# Altium schematic sheet Pag4_Processador.SchDoc(Pag4_Processador)
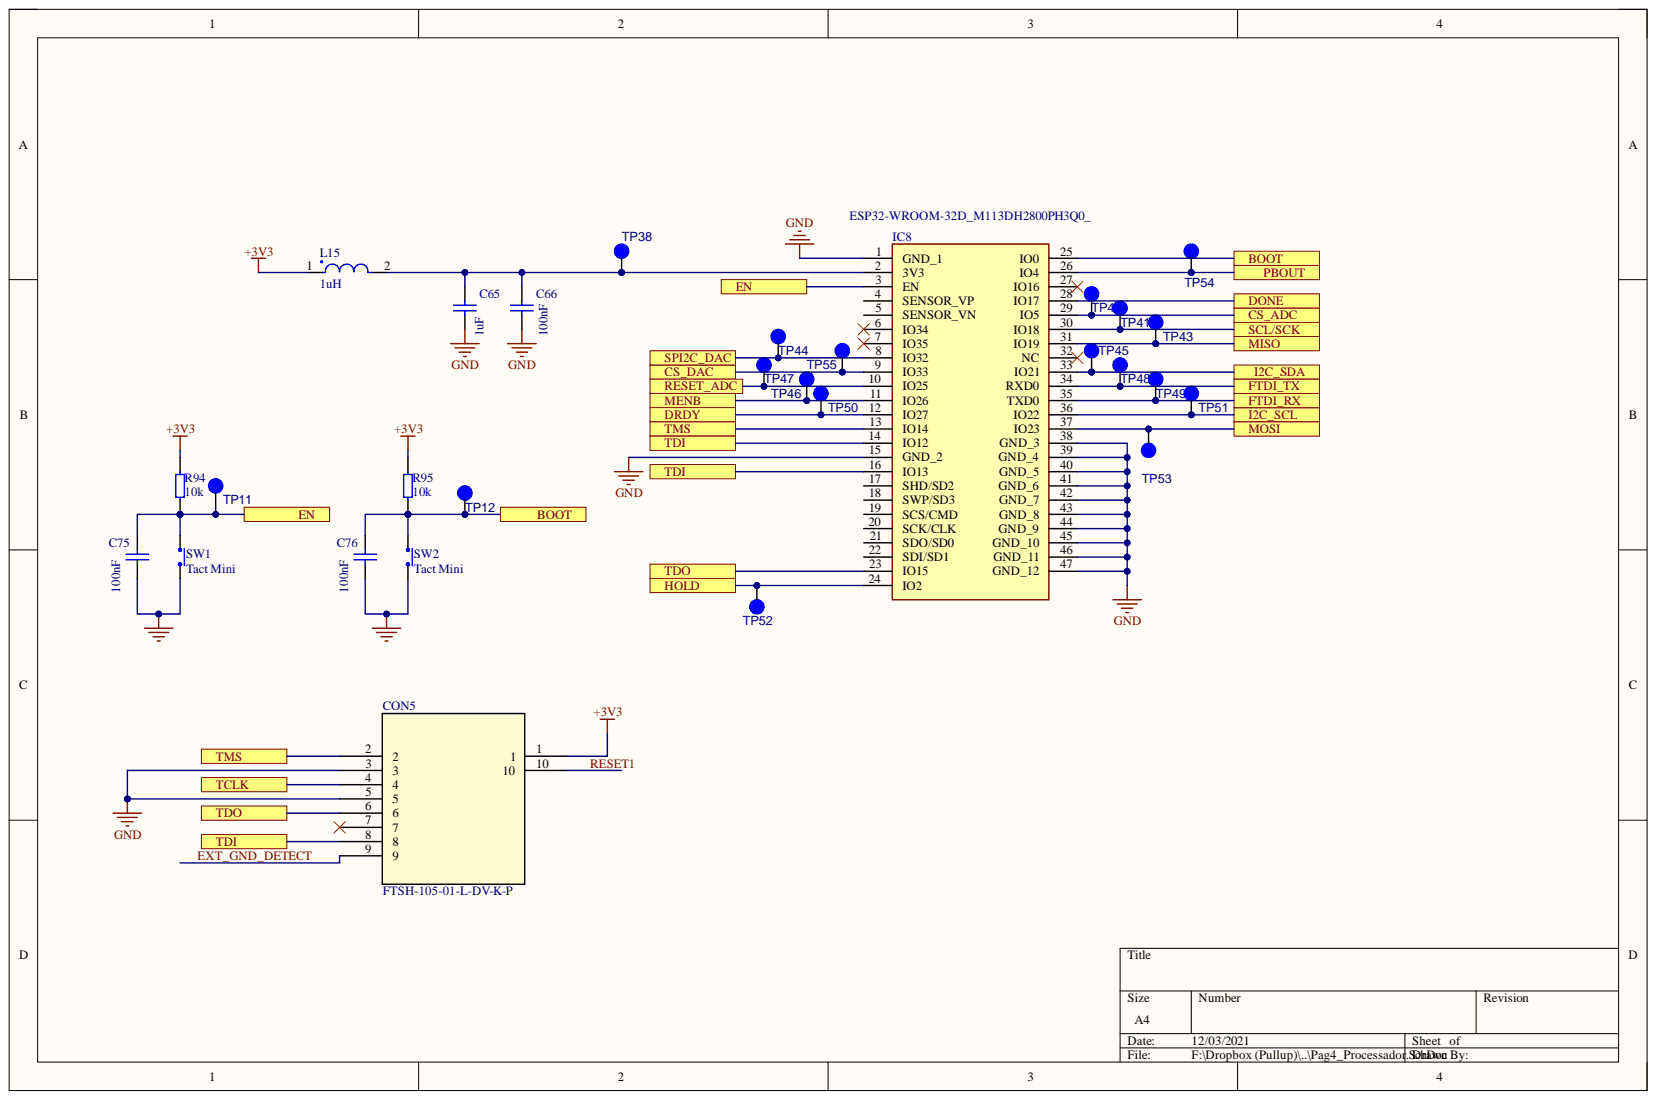
[diagram p0: Pag4_Processador.SchDoc]

## Parts
C65  1uF  [0603]
C66  100nF  [0603]
C75  100nF  [0603]
C76  100nF  [0603]
CON5  FTSH-105-01-L-DV-K-P  [CON10_2X5_DUK_FTSH_SAI]
IC8  ESP32-WROOM-32D_M113DH2800PH3Q0_  [ESP32WROOM32DM113DH2800PH3Q0]
L15  1uH  [SRP4012TA]
R94  10k  [0603]
R95  10k  [0603]
SW1  Tact Mini  [MSLPT5252BG1MTR_pullup]
SW2  Tact Mini  [MSLPT5252BG1MTR_pullup]
TP11  =value  [TEST]
TP12  =value  [TEST]
TP38  =value  [TEST]
TP40  =value  [TEST]
TP41  =value  [TEST]
TP43  =value  [TEST]
TP44  =value  [TEST]
TP45  =value  [TEST]
TP46  =value  [TEST]
TP47  =value  [TEST]
TP48  =value  [TEST]
TP49  =value  [TEST]
TP50  =value  [TEST]
TP51  =value  [TEST]
TP52  =value  [TEST]
TP53  =value  [TEST]
TP54  =value  [TEST]
TP55  =value  [TEST]

## Ports
BOOT, CS_ADC, CS_DAC, DONE, DRDY, EN, FTDI_RX, FTDI_TX, HOLD, I2C_SCL, I2C_SDA, MENB, MISO, MOSI, PBOUT, RESET_ADC, SCL/SCK, SPI2C_DAC, TCLK, TDI, TDO, TMS

## Nets
+3V3: CON5.1 L15.1 R94.2 R95.2
GND: C65.1 C66.1 C75.1 C76.1 CON5.3 CON5.5 IC8.1(GND_1) IC8.15(GND_2) IC8.38(GND_3) IC8.39(GND_4) IC8.40(GND_5) IC8.41(GND_6) IC8.42(GND_7) IC8.43(GND_8) IC8.44(GND_9) IC8.45(GND_10) IC8.46(GND_11) IC8.47(GND_12) SW1.1 SW2.1
BOOT: C76.2 IC8.25(IO0) R95.1 SW2.2 TP12.1 ; port BOOT
CS_ADC: IC8.29(IO5) TP40.1 ; port CS_ADC
CS_DAC: IC8.9(IO33) TP55.1 ; port CS_DAC
DONE: IC8.28(IO17) ; port DONE
DRDY: IC8.12(IO27) TP50.1 ; port DRDY
EN: C75.2 IC8.3(EN) R94.1 SW1.2 TP11.1 ; port EN
EXT_GND_DETECT: CON5.9
FTDI_RX: IC8.35(TXD0) TP49.1 ; port FTDI_RX
FTDI_TX: IC8.34(RXD0) TP48.1 ; port FTDI_TX
HOLD: IC8.24(IO2) TP52.1 ; port HOLD
I2C_SCL: IC8.36(IO22) TP51.1 ; port I2C_SCL
I2C_SDA: IC8.33(IO21) TP45.1 ; port I2C_SDA
MENB: IC8.11(IO26) TP46.1 ; port MENB
MISO: IC8.31(IO19) TP43.1 ; port MISO
MOSI: IC8.37(IO23) TP53.1 ; port MOSI
PBOUT: IC8.26(IO4) TP54.1 ; port PBOUT
RESET1: CON5.10
RESET_ADC: IC8.10(IO25) TP47.1 ; port RESET_ADC
SCL/SCK: IC8.30(IO18) TP41.1 ; port SCL/SCK
SPI2C_DAC: IC8.8(IO32) TP44.1 ; port SPI2C_DAC
TCLK: CON5.4 ; port TCLK
TDI: CON5.8 IC8.14(IO12) IC8.16(IO13) ; port TDI
TDO: CON5.6 IC8.23(IO15) ; port TDO
TMS: CON5.2 IC8.13(IO14) ; port TMS
NetC65_2: C65.2 C66.2 IC8.2(3V3) L15.2 TP38.1

Not connected: CON5 7; IC8 4(SENSOR_VP) 5(SENSOR_VN) 6(IO34) 7(IO35) 17(SHD/SD2) 18(SWP/SD3) 19(SCS/CMD) 20(SCK/CLK) 21(SDO/SD0) 22(SDI/SD1) 27(IO16) 32(NC)

## Library data of the parts
C65 = (no symbol name): Manufacturer: Samsung Electro-Mechanics; MPN: CL10A105KA8NNNC; PCBA: Assembly; Component Kind: Standard; Datasheet: https://www.mouser.com/datasheet/2/585/MLCC-1837944.pdf; Supplier 1: Mouser; Supplier 1 Link: https://www.mouser.com/ProductDetail/Samsung-Electro-Mechanics/CL10A105KA8NNNC?qs=hqM3L16%252BxldY%252BnK4KzDJVg%3D%3D; Supplier 1 Part Number: 187-CL10A105KA8NNNC; Value: 1uF; Library Name: potenciostato.SCHLIB
C66 C75 C76 = (no symbol name): Capacitor Ceramic 100nF 10% 16V 0603 (1608 Metric); Manufacturer: Kemet; MPN: C0603C104K4RACTU; Alternate: AA; CPqD PN: Pullup_Cap_100nF_0603_CAP; Engineering Change Status Code: Approved; Extra Info: - 55 C to + 125 C; LeadTime: 12 wks; Model_File: CAP; PCB INFO: Assembly; Temperature Coefficient: X7R; Complement Value: 16V; Component Kind: Standard; Component Type: Standard; Package: 0603 (1608 Metric); Price: 0,012; RoHS: SIM; Size / Dimension: 0.8 mm W x 1.6 mm L x 0.8 mm H; SVN_Link Data Sheet: http://svn.cpqd.com.br/DRT/biblio_comp/tags/datasheets/capacitor/kemet.pdf; Tolerance: 10%; Type: Capacitor Ceramic; Value: 100nF; Web_Link Data Sheet: http://www.kemet.com/kemet/web/homepage/kechome.nsf/vapubfiles/KEM_C1002_X7R_SMD.pdf/$file/KEM_C1002_X7R_SMD.pdf; Library Name: potenciostato.SCHLIB
CON5 = FTSH-105-01-L-DV-K-P: No Description Available; Manufacturer: Samtec; Mfr_Name: Samtec Inc; MPN: FTSH-105-01-L-DV-K-P-TR; PCBA: Assembly; Assembly: Assembly; Component Kind: Standard; Datasheet: https://www.mouser.com/datasheet/2/527/ftsh_smt-1316912.pdf; Library Name: FTSH-105-01-L-DV-K-P.SchLib; Manufacturer_Part_Number: FTSH-105-01-L-DV-K-P; RefDes: RefDes; Supplier 1: Mouser; Supplier 1 Link: https://www.mouser.com/ProductDetail/Samtec/FTSH-105-01-L-DV-K-P-TR?qs=fnpEE5GccuMUjCRgxv4voQ%3D%3D; Supplier 1 Part Number: 200-FTSH10501LDVKPTR; Type: DEV; Copyright: Copyright (C) 2021 Ultra Librarian. All rights reserved.
IC8 = ESP32-WROOM-32D_M113DH2800PH3Q0_: Integrated Circuit; Manufacturer_Name: Espressif Systems; Manufacturer_Part_Number: ESP32-WROOM-32D(M113DH2800PH3Q0); Component Kind: Standard; Datasheet Link: https://www.espressif.com/sites/default/files/documentation/esp32-wroom-32d_esp32-wroom-32u_datasheet_en.pdf; Height: 3.2mm
L15 = (no symbol name): Manufacturer: Bourns; MPN: SRP4012TA-1R0M; PCBA: Assembly; Component Kind: Standard; Datasheet: https://www.mouser.com/datasheet/2/54/rp4012ta-1391620.pdf; Supplier 1: Mouser; Supplier 1 Link: https://www.mouser.com/ProductDetail/Bourns/SRP4012TA-1R0M?qs=%2Fha2pyFaduhnZC9XlWRPjS9IbC2g%252Bs6L7AFI%2FwucAauXoSPPWYzFLg%3D%3D; Supplier 1 Part Number: 652-SRP4012TA-1R0M; Value: 1uH; Library Name: potenciostato.SCHLIB
R94 R95 = (no symbol name): Manufacturer: Yageo; MPN: RC0603JR-07100KL; PCBA: Assembly; Value: 100K; Component Kind: Standard; Datasheet: https://www.mouser.com/datasheet/2/447/PYu-RC_Group_51_RoHS_L_10-1527934.pdf; Supplier 1: Mouser; Supplier 1 Link: https://www.mouser.com/ProductDetail/Yageo/RC0603JR-07100KL?qs=sGAEpiMZZMu61qfTUdNhG5eFuApKbqVdI3BI7%252bGKRK0%3d; Supplier 1 Part Number: 603-RC0603JR-07100KL; Library Name: potenciostato.SCHLIB
SW1 SW2 = Switch-PB-6.2x6.3mm-SMD: Tactile Switch, SPNO, SMD, 160gf, 6.2x6.3x2.5mm; Manufacturer: TE Connectivity / P&B; MPN: 506-MSLPT5252BG1MTR; Database Table Name: Rele_Switch$; PCBA: Assembly; Component Kind: Standard; Library Name: Nordic Discrete DB.DbLib; Supplier 1: Mouser; Supplier 1 Link: https://br.mouser.com/ProductDetail/TE-Connectivity-PB/MSLPT5252BG1MTR?qs=%2Fha2pyFaduhIiQRTmF0KhjdLhHvdrMUkm%252B0P6S0AVaLaZTgFrXzMZcXO%2F1lNtvPt; Supplier Part Number 1: 506-MSLPT5252BG1MTR; Value: Tact Mini
TP11 TP12 TP38 TP40 TP41 TP43-TP55 = TESTPOINT: Component Kind: Standard; Library Name: potenciostato.SCHLIB
